# Altium schematic sheet RTC.SchDoc(RTC)
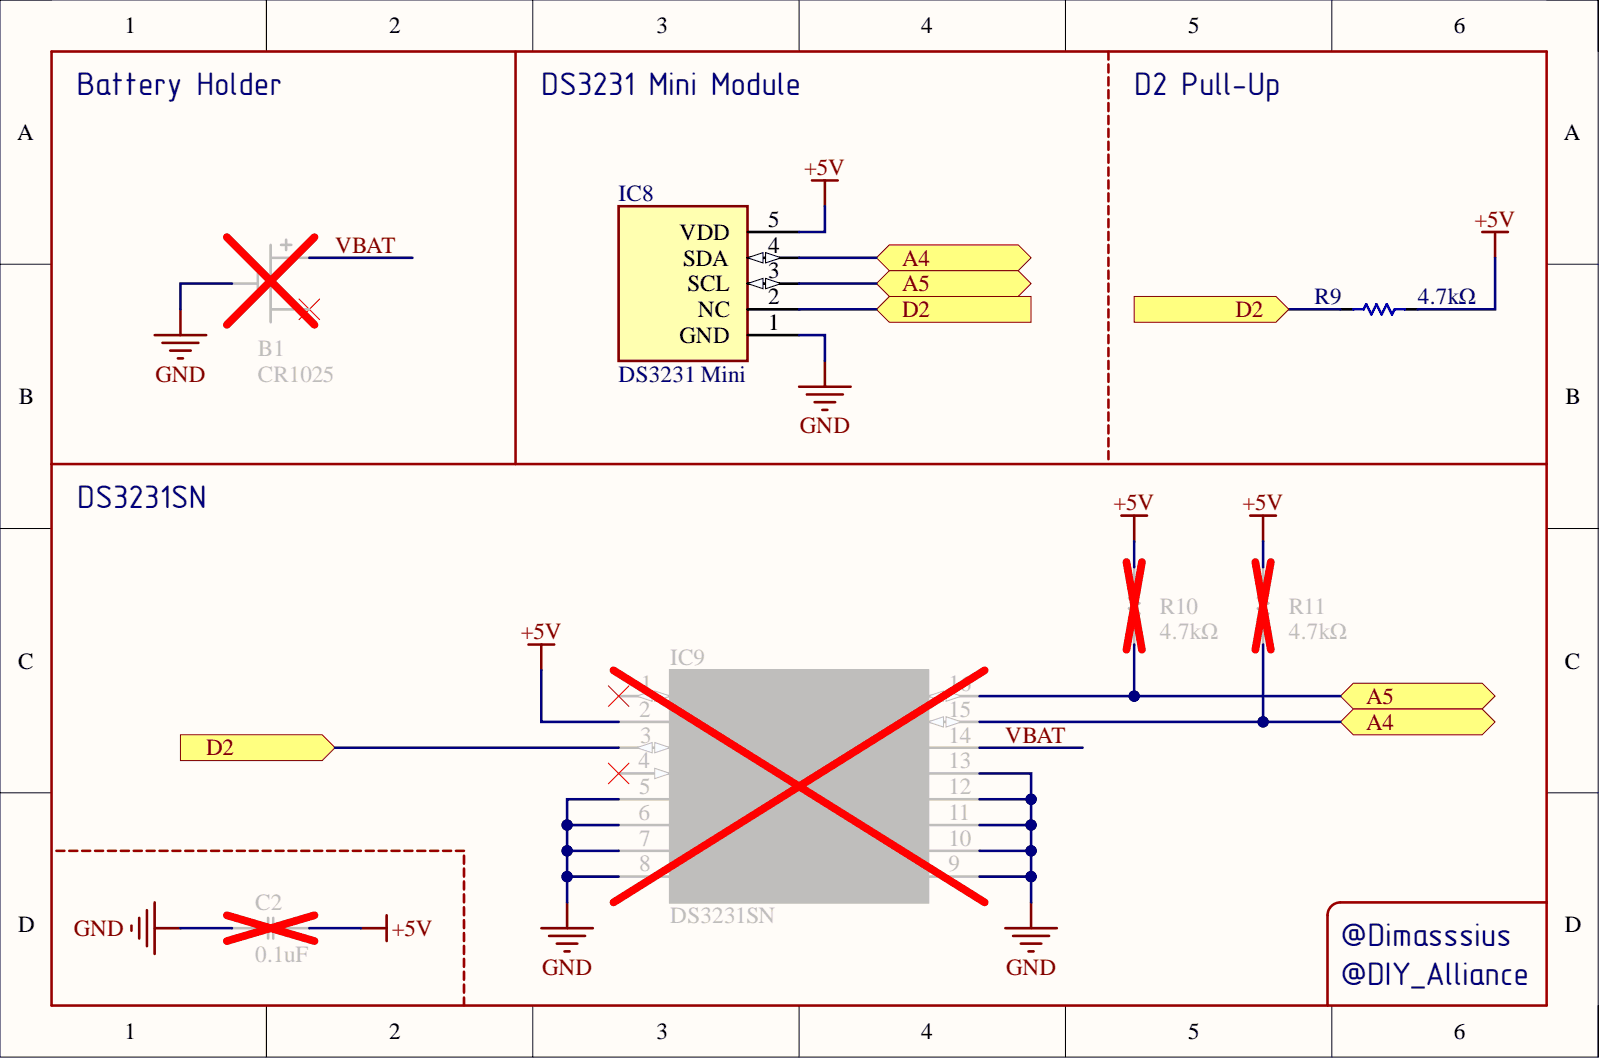
[diagram p0: RTC.SchDoc]

## Parts
B1  CR1025  [CR1025]
C2  0.1uF  [CAP_SMD_MLCC_C0603_(M1608)_C0402A_(M1005A)]
IC8  DS3231 Mini  [DS3231 Mini - Uspide Down]
IC9  DS3231SN  [SOIC127P1032X265-16N]
R9  4.7kΩ  [RES_THD/SMD_VERTICAL_R0603_R0402_4.7K_THD]
R9
R9
R10  4.7kΩ  [RES_SMD_R0603_(M1608)_R0402A_(M1005A)]
R11  4.7kΩ  [RES_SMD_R0603_(M1608)_R0402A_(M1005A)]

## Ports
A4, A5, D2

## Nets
+5V: C2.2 IC8.5(VDD) IC9.2(VCC) R9.2 R10.2 R11.2
GND: B1.1 C2.1 IC8.1(GND) IC9.5(N.C._1) IC9.6(N.C._2) IC9.7(N.C._3) IC9.8(N.C._4) IC9.9(N.C._5) IC9.10(N.C._6) IC9.11(N.C._7) IC9.12(N.C._8) IC9.13(GND)
A4: IC8.4(SDA) IC9.15(SDA) R11.1 ; port A4
A5: IC8.3(SCL) IC9.16(SCL) R10.1 ; port A5
D2: IC8.2(NC) IC9.3(INT/SQW) R9.1 ; port D2
VBAT: B1.2 IC9.14(VBAT)

Not connected: B1 3; IC9 1(32KHZ) 4(RST)

## Library data of the parts
B1 = CR1025: Battery Clip Coin, SMD, CR1025, Cell; Component Kind: Standard; Library Name: SamacSys.SchLib; Value: CR1025
C2 = CAP_SMD_MLCC_0.1UF_6.3V: Capacitor, Ceramic (MLCC), SMD, 100nF, 6.3V, ±10%, X7R, Multilayer Ceramic Capacitors MLCC - SMD/SMT, ROHS; Manufacturer: Murata Electronics North America; Part Number: LLM315R71C104MA11L; @ qty: 0; Minimum Quantity: 1; Tolerance: ±20%; Unit Price (USD): 0.9; Voltage: 16V; Applications: Bypass, Decoupling; Component Kind: Standard; Datasheets: http://www.murata.com/~/media/webrenewal/support/library/catalog/products/capacitor/mlcc/c02e.pdf; Digi-Key Part Number: 490-4495-1-ND; Factory Stock: 0; Features: Low ESL (Multi-Terminal); Height - Seated (Max): -; Image: //media.digikey.com/Renders/Murata%20Renders/1206(LLM315).jpg; Lead Spacing: -; Lead Style: -; Library Name: Capacitors.SchLib; Mounting Type: Surface Mount, MLCC; Operating Temperature: -55°C ~ 125°C; Package / Case: -; Packaging: Cut Tape (CT); Part Status: Active; Quantity Available: 2915; Ratings: -; Series: LLM; Size / Dimension: -; Temperature Coefficient: X7R; Thickness (Max): -; Value: 0.1uF
IC8 = DS3231 Mini: Development Board, Real Time Clock; Component Kind: Standard; Library Name: SamacSys.SchLib; Value: DS3231 Mini
IC9 = DS3231SN: Real Time Clock, SOIC-16-300mil, I2C, Real Time Clocks, ROHS; Component Kind: Standard; Datasheet Link: https://datasheet.datasheetarchive.com/originals/distributors/Datasheets-8/DSA-148585.pdf; Height: 2.65mm; Library Name: SamacSys.SchLib; Value: DS3231SN
R9 = RES_THD/SMD_4.7KΩ_R0603_R0402_THD: Resistor, Metal Film Resistor, Through Hole, D2.3xL6.5mm, 4.7kΩ, ±1%, 250mW, Through Hole Resistors, ROHS; Component Kind: Standard; Library Name: Resistors.SchLib; Value: 4.7kΩ
R10 R11 = RES_SMD_4.7KΩ: Resistor, Thick Film Resistor, SMD, 4.7kΩ, ±5%, Chip Resistor - Surface Mount, ROHS; Component Kind: Standard; Library Name: Resistors.SchLib; Value: 4.7kΩ
